# Altium schematic sheet DIY_DAP_PCB_Audio.SchDoc(DIY_DAP_PCB_Audio)
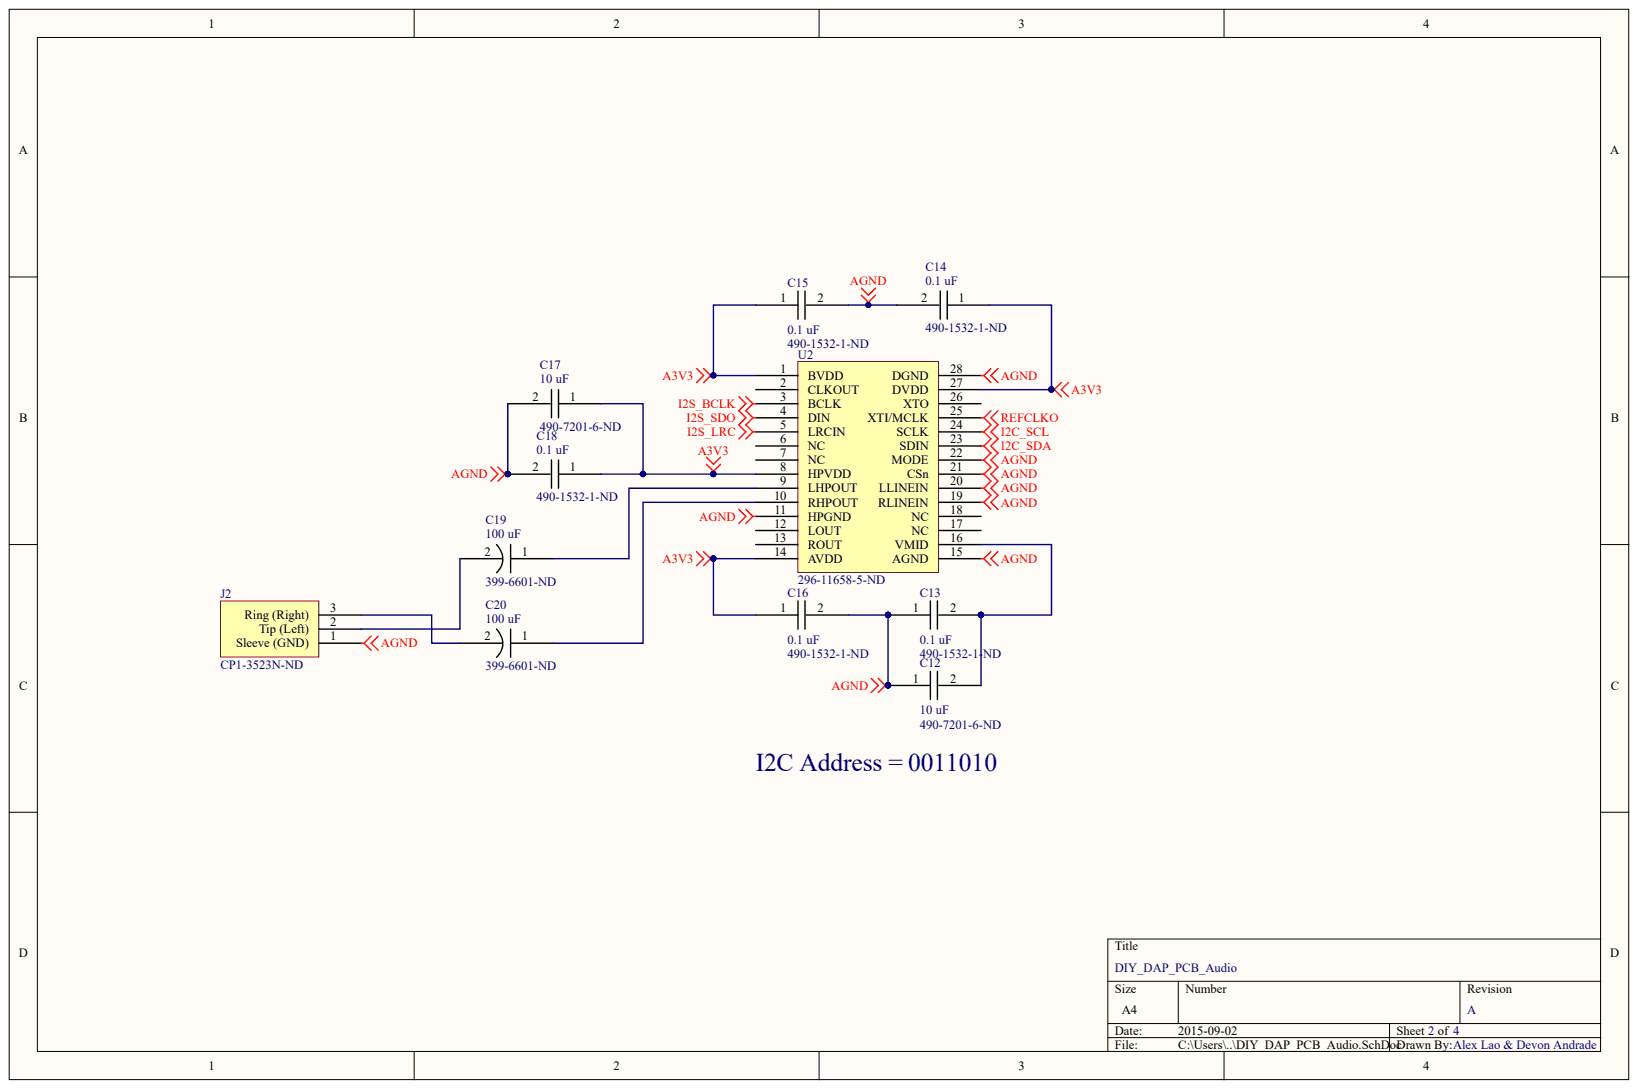
[diagram p0: DIY_DAP_PCB_Audio.SchDoc]

## Parts
C12  490-7201-6-ND
C13  490-1532-1-ND
C14  490-1532-1-ND
C15  490-1532-1-ND
C16  490-1532-1-ND
C17  490-7201-6-ND
C18  490-1532-1-ND
C19  399-6601-ND
C20  399-6601-ND
J2  CP1-3523N-ND
U2  296-11658-5-ND

## Nets
A3V3: C14.1 C15.1 C16.1 C17.1 C18.1 U2.1(BVDD) U2.8(HPVDD) U2.14(AVDD) U2.27(DVDD)
AGND: C12.1 C13.1 C14.2 C15.2 C16.2 C17.2 C18.2 J2.1 U2.11(HPGND) U2.15(AGND) U2.19(RLINEIN) U2.20(LLINEIN) U2.21(CSn) U2.22(MODE) U2.28(DGND)
I2C_SCL: U2.24(SCLK)
I2C_SDA: U2.23(SDIN)
I2S_BCLK: U2.3(BCLK)
I2S_LRC: U2.5(LRCIN)
I2S_SDO: U2.4(DIN)
REFCLKO: U2.25(XTI/MCLK)
NetC12_2: C12.2 C13.2 U2.16(VMID)
NetC19_1: C19.1 U2.9(LHPOUT)
NetC19_2: C19.2 J2.2
NetC20_1: C20.1 U2.10(RHPOUT)
NetC20_2: C20.2 J2.3

Not connected: U2 2(CLKOUT) 6(NC) 7(NC) 12(LOUT) 13(ROUT) 17(NC) 18(NC) 26(XTO)

## Library data of the parts
C12-C20 J2 U2 = (no symbol name): Supplier 1: Digi-Key
